# Altium schematic sheet BEMF_Sensing.SchDoc
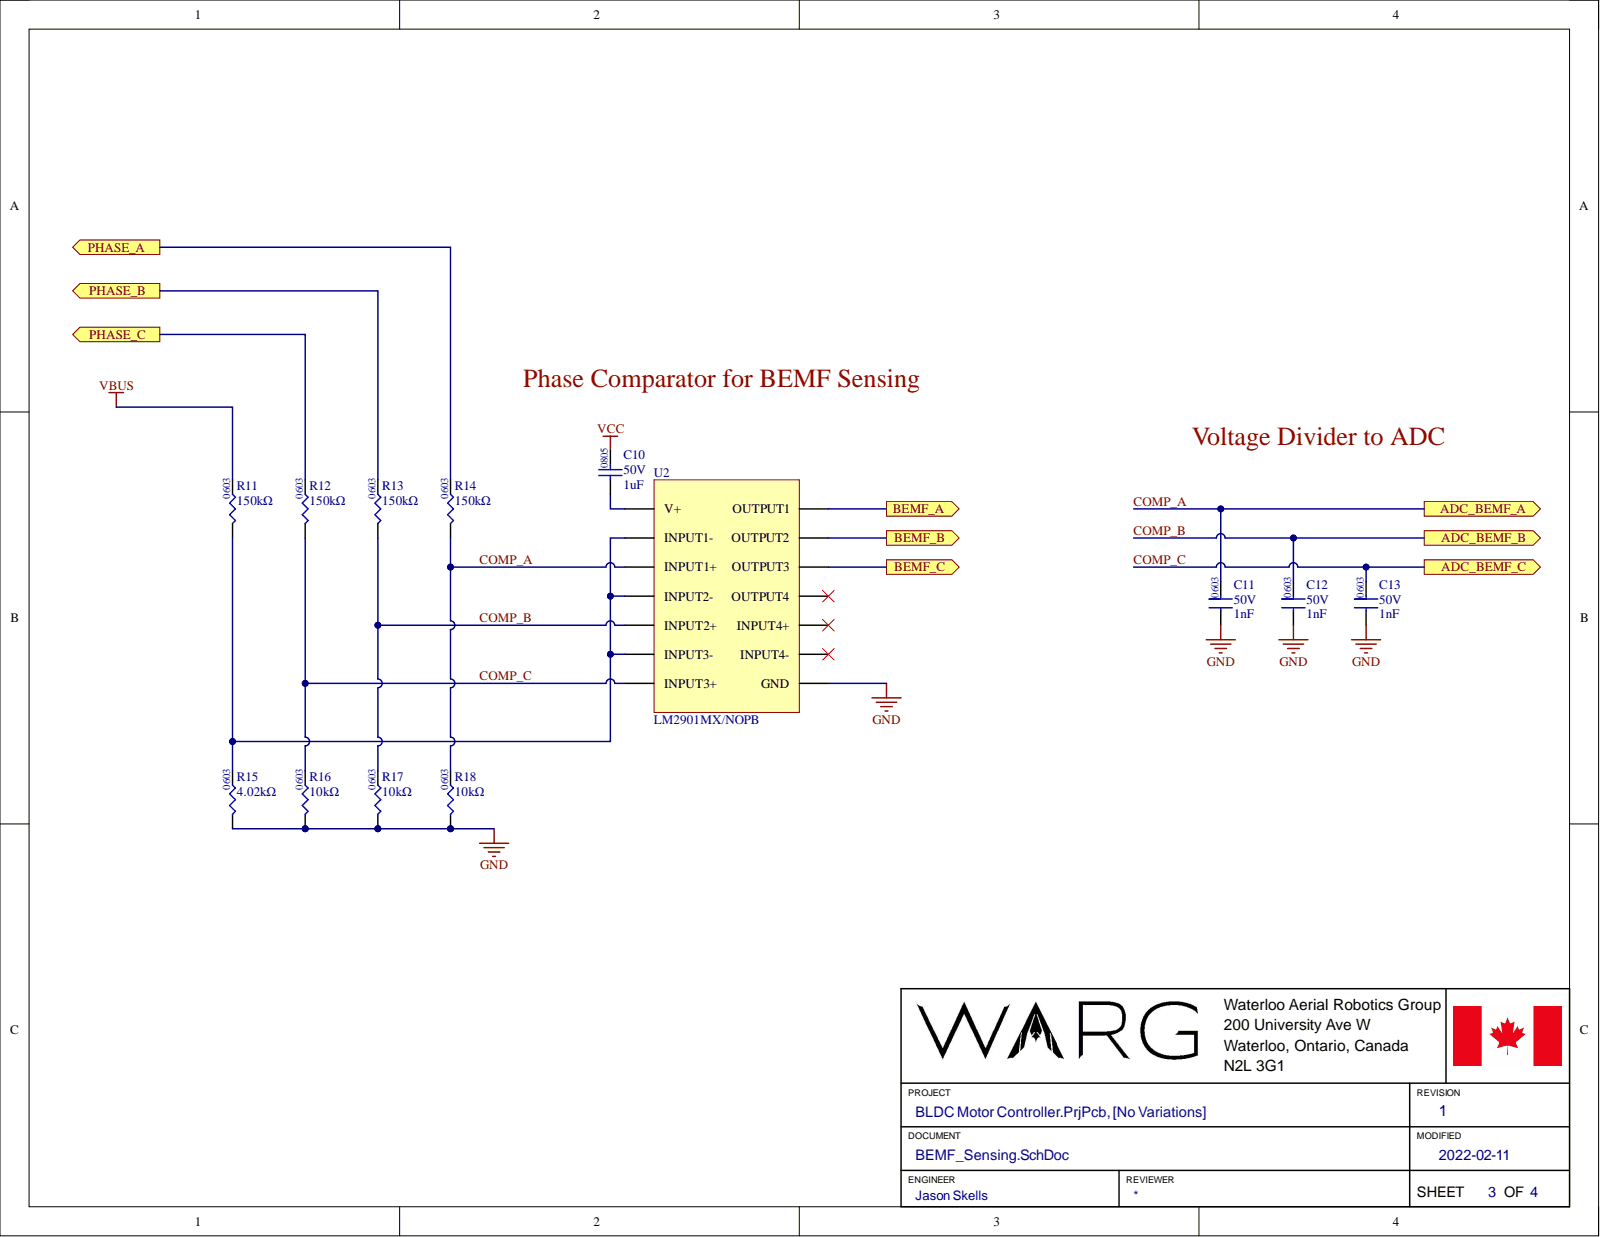
[diagram p0: BEMF_Sensing.SchDoc]

## Parts
C10  value 1uF  Package: 0805  Voltage: 50V  [CAP0805]  (hidden comment: CAP_1uF_50V_0805)
C11  Capacitance: 1nF  Voltage: 50V  [CAP0603]  (hidden comment: CAP_1nF_50V_0603)
C12  Capacitance: 1nF  Voltage: 50V  [CAP0603]  (hidden comment: CAP_1nF_50V_0603)
C13  Capacitance: 1nF  Voltage: 50V  [CAP0603]  (hidden comment: CAP_1nF_50V_0603)
R11  Case Code (Imperial): 0603  Resistance: 150kΩ  [RES0603]  (hidden comment: RES_150kΩ_0603_1%)
R12  Case Code (Imperial): 0603  Resistance: 150kΩ  [RES0603]  (hidden comment: RES_150kΩ_0603_1%)
R13  Case Code (Imperial): 0603  Resistance: 150kΩ  [RES0603]  (hidden comment: RES_150kΩ_0603_1%)
R14  Case Code (Imperial): 0603  Resistance: 150kΩ  [RES0603]  (hidden comment: RES_150kΩ_0603_1%)
R15  Case Code (Imperial): 0603  Resistance: 4.02kΩ  [RES0603]  (hidden comment: RES_4.02Ω_0603_5%)
R16  value 10kΩ  Package: 0603  [RES0603]  (hidden comment: RES_10kΩ_0603_1%)
R17  value 10kΩ  Package: 0603  [RES0603]  (hidden comment: RES_10kΩ_0603_1%)
R18  value 10kΩ  Package: 0603  [RES0603]  (hidden comment: RES_10kΩ_0603_1%)
U2  LM2901MX  Manufacturer Part Number: LM2901MX/NOPB  [COMPARATOR_SOP14 - duplicate]

## Ports
ADC_BEMF_A, ADC_BEMF_B, ADC_BEMF_C, BEMF_A, BEMF_B, BEMF_C, PHASE_A, PHASE_B, PHASE_C

## Nets
GND: C11.1 C12.1 C13.1 R15.1 R16.1 R17.1 R18.1 U2.12(GND)
VBUS: R11.2
VCC: C10.2
BEMF_A: U2.2(OUTPUT1) ; port BEMF_A
BEMF_B: U2.1(OUTPUT2) ; port BEMF_B
BEMF_C: U2.14(OUTPUT3) ; port BEMF_C
COMP_A: C11.2 R14.1 R18.2 U2.5(INPUT1+) ; port ADC_BEMF_A
COMP_B: C12.2 R13.1 R17.2 U2.7(INPUT2+) ; port ADC_BEMF_B
COMP_C: C13.2 R12.1 R16.2 U2.9(INPUT3+) ; port ADC_BEMF_C
PHASE_A: R14.2 ; port PHASE_A
PHASE_B: R13.2 ; port PHASE_B
PHASE_C: R12.2 ; port PHASE_C
NetC10_1: C10.1 U2.3(V+)
NetR11_1: R11.1 R15.2 U2.4(INPUT1-) U2.6(INPUT2-) U2.8(INPUT3-)

Not connected: U2 10(INPUT4-) 11(INPUT4+) 13(OUTPUT4)

## Library data of the parts
C10 = CAP_1uF_50V_0805_MURATA: CAP CER 1UF 50V X7R ±10% 0805; Manufacturer 1: Murata Electronics; Manufacturer Part Number 1: GCJ21BR71H105KA01L; Component Kind: Standard; Mounting Type: Surface Mount; Supplier 1: Digi-Key; Supplier Part Number 1: 490-10667-1-ND; Temperature Coefficient: X7R; Tolerance: ±10%; Library Name: WARG.SchLib
C11-C13 = CAP_1nF_50V_0603: CAP CER 1nF 50V X7R ±10% 0603; Manufacturer: Murata; Manufacturer Part Number: GCM155R71H102KA37D; Case Code (Imperial): 0402; Case Code (Metric): 1005; Case/Package: 0402; Category: Ceramic Capacitors; Component Kind: Standard; ComponentLink1Description: https://datasheet.ciiva.com/130/product-130720.pdf?src-supplier=Digi-Key; ComponentLink1URL: https://datasheet.ciiva.com/130/product-130720.pdf?src-supplier=Digi-Key; ComponentLink2Description: https://datasheet.ciiva.com/3778/3103gcm155r71h102ka3723-3778878.pdf?src-supplier=Arrow+Electronics; ComponentLink2URL: https://datasheet.ciiva.com/3778/3103gcm155r71h102ka3723-3778878.pdf?src-supplier=Arrow+Electronics; ComponentLink3Description: https://datasheet.ciiva.com/18183/getdatasheetpartid-140970-18183520.pdf?src-supplier=Verical; ComponentLink3URL: https://datasheet.ciiva.com/18183/getdatasheetpartid-140970-18183520.pdf?src-supplier=Verical; ComponentLink4Description: https://datasheet.ciiva.com/11786/0900766b80ef92d0-11786143.pdf?src-supplier=RSComponents; ComponentLink4URL: https://datasheet.ciiva.com/11786/0900766b80ef92d0-11786143.pdf?src-supplier=RSComponents; ComponentLink5Description: https://datasheet.ciiva.com/16213/product-16213384.pdf?src-supplier=Future+Electronics; ComponentLink5URL: https://datasheet.ciiva.com/16213/product-16213384.pdf?src-supplier=Future+Electronics; Construction: Flat; Depth: 0.5mm; Dielectric: X7R; Height: 0.5mm; Lead Free: Lead Free; Length: 1mm; Library Name: motor_controller_schem_lib.SchLib; Max Operating Temperature: 125°C; Min Operating Temperature: -55°C; Mount: Surface Mount; Package Quantity: 1; Packaging: Tape and Reel; Pricing 1: 1=0.10000, 10=0.03200, 50=0.01740, 100=0.01420, 500=0.01012, 1000=0.00796, 2500=0.00723, 5000=0.00665 (USD); Radiation Hardening: No; Ratings: AEC-Q200; REACH SVHC: No SVHC; RoHS: Yes; RoHS Compliant: Yes; Series: GCM; Stock 1: 17134775; Supplier 1: Digi-Key; Supplier Part Number 1: 490-4761-1-ND; Termination: SMD/SMT; Thickness: 0.022inch; Tolerance: 10%; Voltage Rating: 50V; Voltage Rating (DC): 50V; Width: 0.02inch
R11-R14 = RES_150kΩ_0603_1%: RES 150k OHM ±1% 1/10W 0603; Manufacturer: Yageo; Manufacturer Part Number: RC0603FR-07150KL; Max Power Dissipation: 100mW; Temperature Coefficient: 100ppm/°C; Voltage Rating: 50V; Case Code (Metric): 1608; Case/Package: 0603; Category: Chip SMD Resistors; Component Kind: Standard; ComponentLink1Description: https://datasheet.ciiva.com/2454/pyu-r-int-thick-7-2454078.pdf?src-supplier=Digi-Key; ComponentLink1URL: https://datasheet.ciiva.com/2454/pyu-r-int-thick-7-2454078.pdf?src-supplier=Digi-Key; ComponentLink2Description: https://datasheet.ciiva.com/2454/pyu-r-int-thick-7-2454079.pdf?src-supplier=Digi-Key; ComponentLink2URL: https://datasheet.ciiva.com/2454/pyu-r-int-thick-7-2454079.pdf?src-supplier=Digi-Key; ComponentLink3Description: https://datasheet.ciiva.com/2454/pyu-r-int-thick-7-2454080.pdf?src-supplier=Digi-Key; ComponentLink3URL: https://datasheet.ciiva.com/2454/pyu-r-int-thick-7-2454080.pdf?src-supplier=Digi-Key; ComponentLink4Description: https://datasheet.ciiva.com/46412/443971-46412843.pdf?src-supplier=Element14; ComponentLink4URL: https://datasheet.ciiva.com/46412/443971-46412843.pdf?src-supplier=Element14; ComponentLink5Description: https://datasheet.ciiva.com/46440/1868787-46440892.pdf?src-supplier=Element14; ComponentLink5URL: https://datasheet.ciiva.com/46440/1868787-46440892.pdf?src-supplier=Element14; ComponentLink6Description: https://datasheet.ciiva.com/15189/getdatasheetpartid-326478-15189611.pdf?src-supplier=Verical; ComponentLink6URL: https://datasheet.ciiva.com/15189/getdatasheetpartid-326478-15189611.pdf?src-supplier=Verical; ComponentLink7Description: https://datasheet.ciiva.com/7746/443971-7746254.pdf?src-supplier=Element14; ComponentLink7URL: https://datasheet.ciiva.com/7746/443971-7746254.pdf?src-supplier=Element14; ComponentLink8Description: https://datasheet.ciiva.com/7746/443971-7746255.pdf?src-supplier=Element14; ComponentLink8URL: https://datasheet.ciiva.com/7746/443971-7746255.pdf?src-supplier=Element14; Construction: Rectangular; Depth: 0.8mm; Features: Moisture Resistant; Height: 0.022inch; Lead Free: Lead Free; Length: 0.063inch; Library Name: motor_controller_schem_lib.SchLib; Max Operating Temperature: 155°C; Military Standard: Not; Min Operating Temperature: -55°C; Number of Pins: 2; Number of Terminations: 2; Package Quantity: 1; Packaging: Tape and Reel; Power Rating: 100mW; Pricing 1: 1=0.10000, 10=0.02400, 100=0.00970, 1000=0.00436, 2500=0.00378 (USD); REACH SVHC: No SVHC; Resistance Tolerance: 1%; Resistor Type: Thick Film; RoHS: Yes; RoHS Compliant: Yes; Series: RC; Size Code: 0603; Stock 1: 789169; Supplier 1: Digi-Key; Supplier Part Number 1: 311-150KHRCT-ND; Termination: SMD/SMT; Tolerance: 1%; Voltage Rating (DC): 50V; Width: 0.031inch
R15 = RES_4.02kΩ_0603_1%: RES 4.02k OHM ±1% 1/10W 0603; Manufacturer: Yageo; Manufacturer Part Number: RC0603FR-074K02L; Max Power Dissipation: 100mW; Temperature Coefficient: 100ppm/°C; Voltage Rating: 50V; Working Voltage: 75V; Case Code (Metric): 1608; Case/Package: 0603; Category: Chip SMD Resistors; Component Kind: Standard; ComponentLink1Description: https://datasheet.ciiva.com/2454/pyu-r-int-thick-7-2454036.pdf?src-supplier=Digi-Key; ComponentLink1URL: https://datasheet.ciiva.com/2454/pyu-r-int-thick-7-2454036.pdf?src-supplier=Digi-Key; ComponentLink2Description: https://datasheet.ciiva.com/15189/getdatasheetpartid-323806-15189751.pdf?src-supplier=Verical; ComponentLink2URL: https://datasheet.ciiva.com/15189/getdatasheetpartid-323806-15189751.pdf?src-supplier=Verical; Construction: Rectangular; Depth: 0.8mm; Features: Moisture Resistant; Height: 0.022inch; Lead Free: Lead Free; Length: 0.063inch; Library Name: motor_controller_schem_lib.SchLib; Max Operating Temperature: 155°C; Military Standard: Not; Min Operating Temperature: -55°C; Number of Terminations: 2; Package Quantity: 5000; Packaging: Tape and Reel; Power Rating: 100mW; Pricing 1: 1=0.10000, 10=0.02400, 100=0.00970, 1000=0.00436, 2500=0.00378 (USD); Resistor Type: Thick Film; RoHS: Yes; RoHS Compliant: Yes; Series: RC; Stock 1: 60268; Supplier 1: Digi-Key; Supplier Part Number 1: 311-4.02KHRCT-ND; Technology: METAL OXIDE FILM; Termination: SMD/SMT; Tolerance: 1%; Voltage Rating (DC): 50V; Width: 0.031inch
R16-R18 = RES_10kΩ_0603_1%: RES 10K OHM ±1% 1/10W 0603; Manufacturer 1: Yageo; Manufacturer Part Number 1: RC0603FR-0710KL; Component Kind: Standard; Mounting Type: Surface Mount; Power Rating: 1/10W; Supplier 1: Digi-Key; Supplier Part Number 1: 311-10.0KHRCT-ND; Tolerance: ±1%; Library Name: WARG.SchLib
U2 = LM2901MX: Low offset, quad differential comparator, automotive grade 14-SOIC -40 to 85; Manufacturer: Texas Instruments; Input Bias Current: 250nA; Input Offset Voltage (Vos): 7mV; Max Dual Supply Voltage: 18V; Max Power Dissipation: 760mW; Max Supply Voltage: 36V; Min Dual Supply Voltage: 1V; Min Supply Voltage: 2V; Nominal Supply Current: 2mA; Number of Channels: 4; Output Current: 16mA; Response Time: 1.3us; Voltage Gain: 100dB; Case/Package: SOIC; Category: Comparators; Component Kind: Standard; ComponentLink1Description: https://datasheet.ciiva.com/49046/1902682-49046154.pdf?src-supplier=Element14; ComponentLink1URL: https://datasheet.ciiva.com/49046/1902682-49046154.pdf?src-supplier=Element14; ComponentLink2Description: https://datasheet.ciiva.com/46382/1888794-46382543.pdf?src-supplier=Element14; ComponentLink2URL: https://datasheet.ciiva.com/46382/1888794-46382543.pdf?src-supplier=Element14; Contact Plating: Tin,Matte; Lead Free: Lead Free; Library Name: motor_controller_schem_lib.SchLib; Max Operating Temperature: 85°C; Min Operating Temperature: -40°C; Mount: Surface Mount; Number of Elements: 4; Number of Pins: 14; Packaging: Tape and Reel; Power Dissipation: 760mW; Pricing 1: 1=1.05000, 10=0.93800, 25=0.89040, 100=0.73140, 250=0.68368, 500=0.60420, 1000=0.52500 (USD); Quiescent Current: 2mA; Radiation Hardening: No; REACH SVHC: No SVHC; RoHS: Yes; RoHS Compliant: Yes; Stock 1: 29166; Supplier 1: Digi-Key; Supplier Part Number 1: LM2901MX/NOPBCT-ND
